# Altium schematic sheet 2.4G 数传.SchDoc
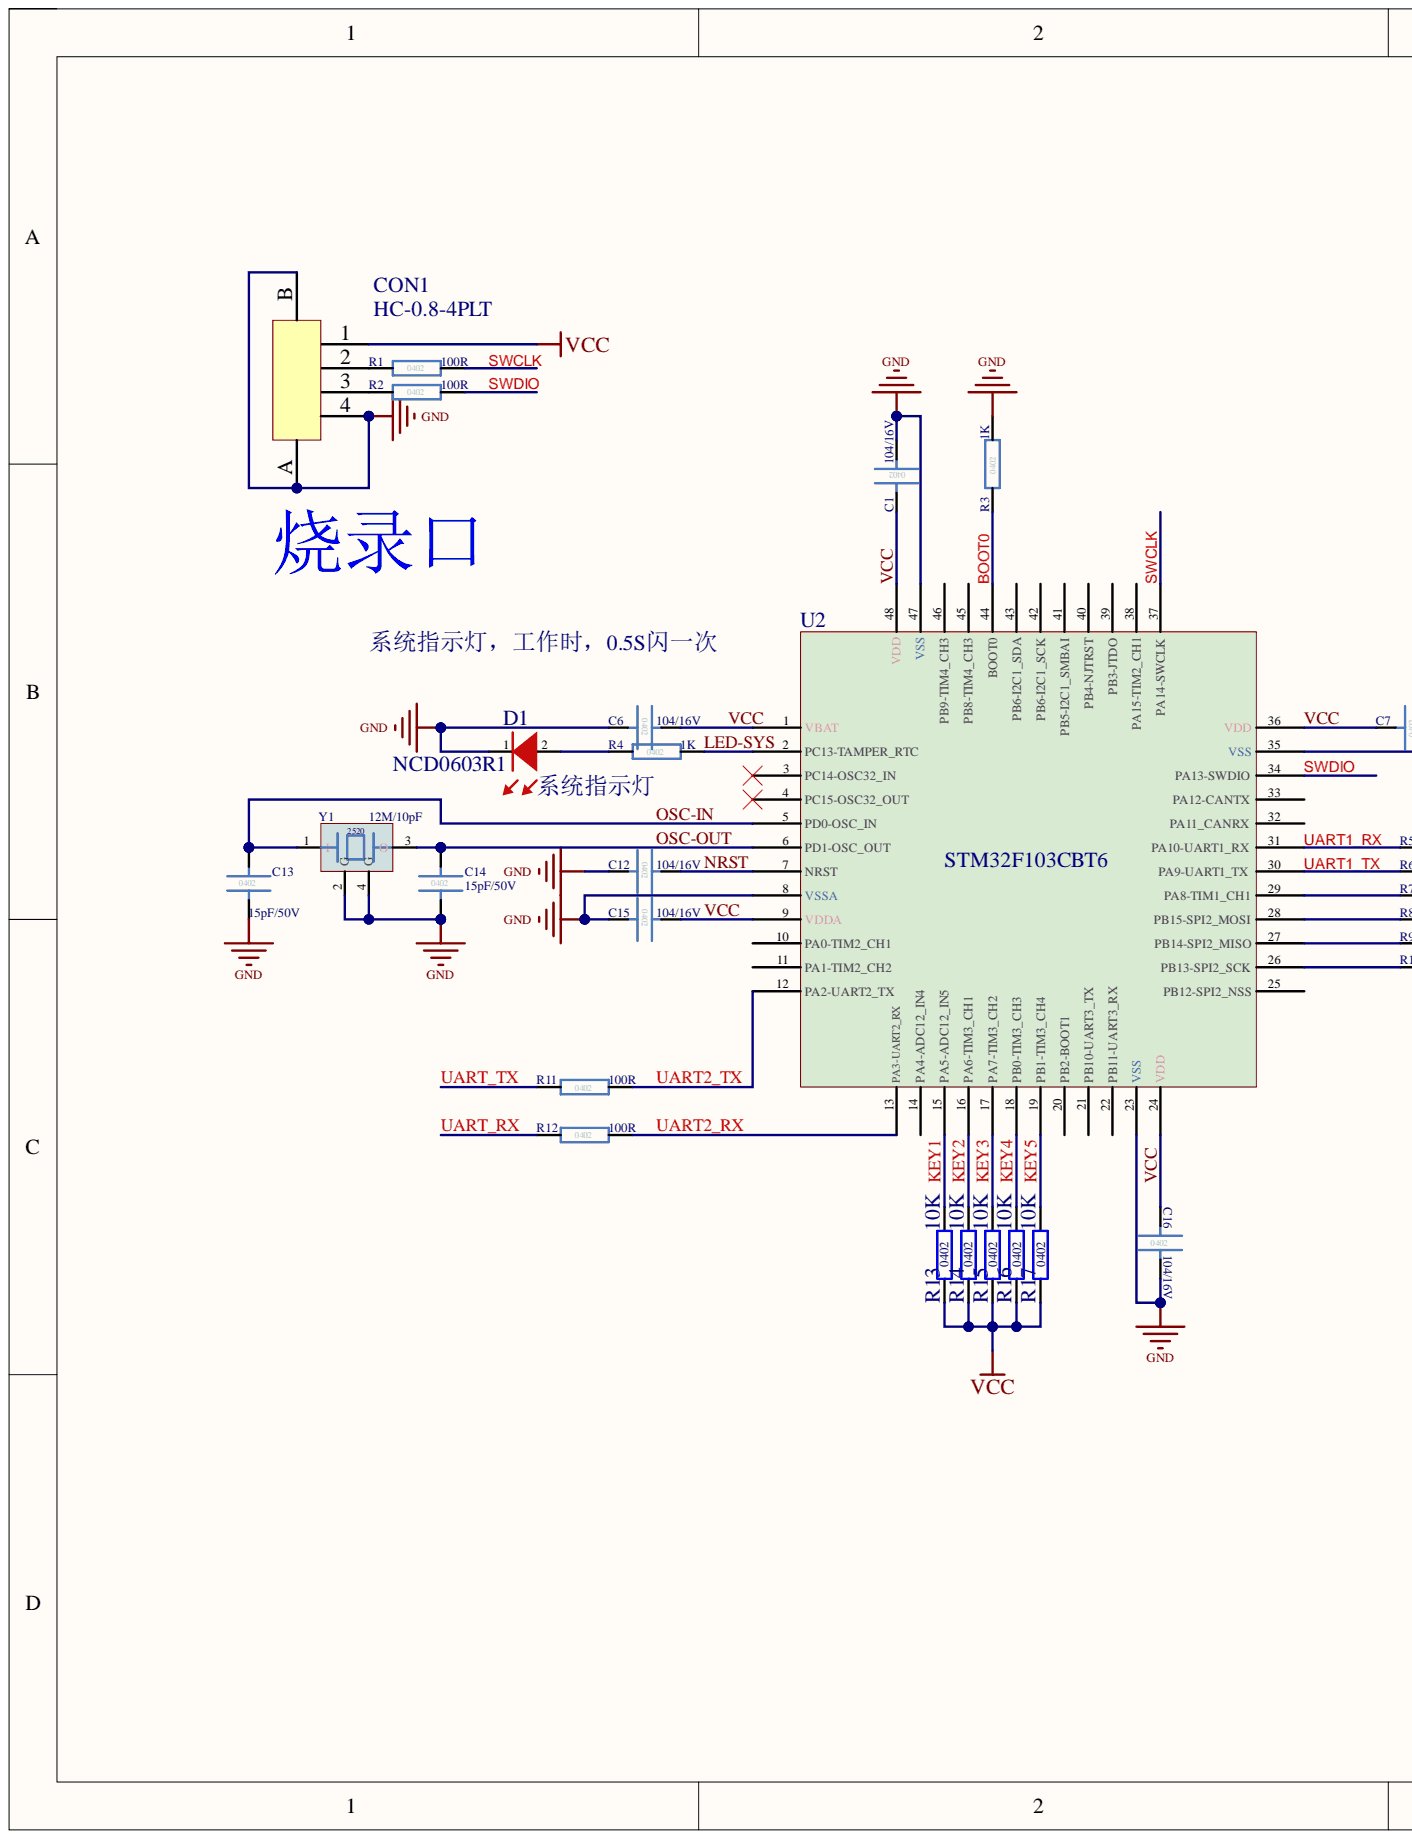
[diagram p0: 2.4G 数传.SchDoc, left]
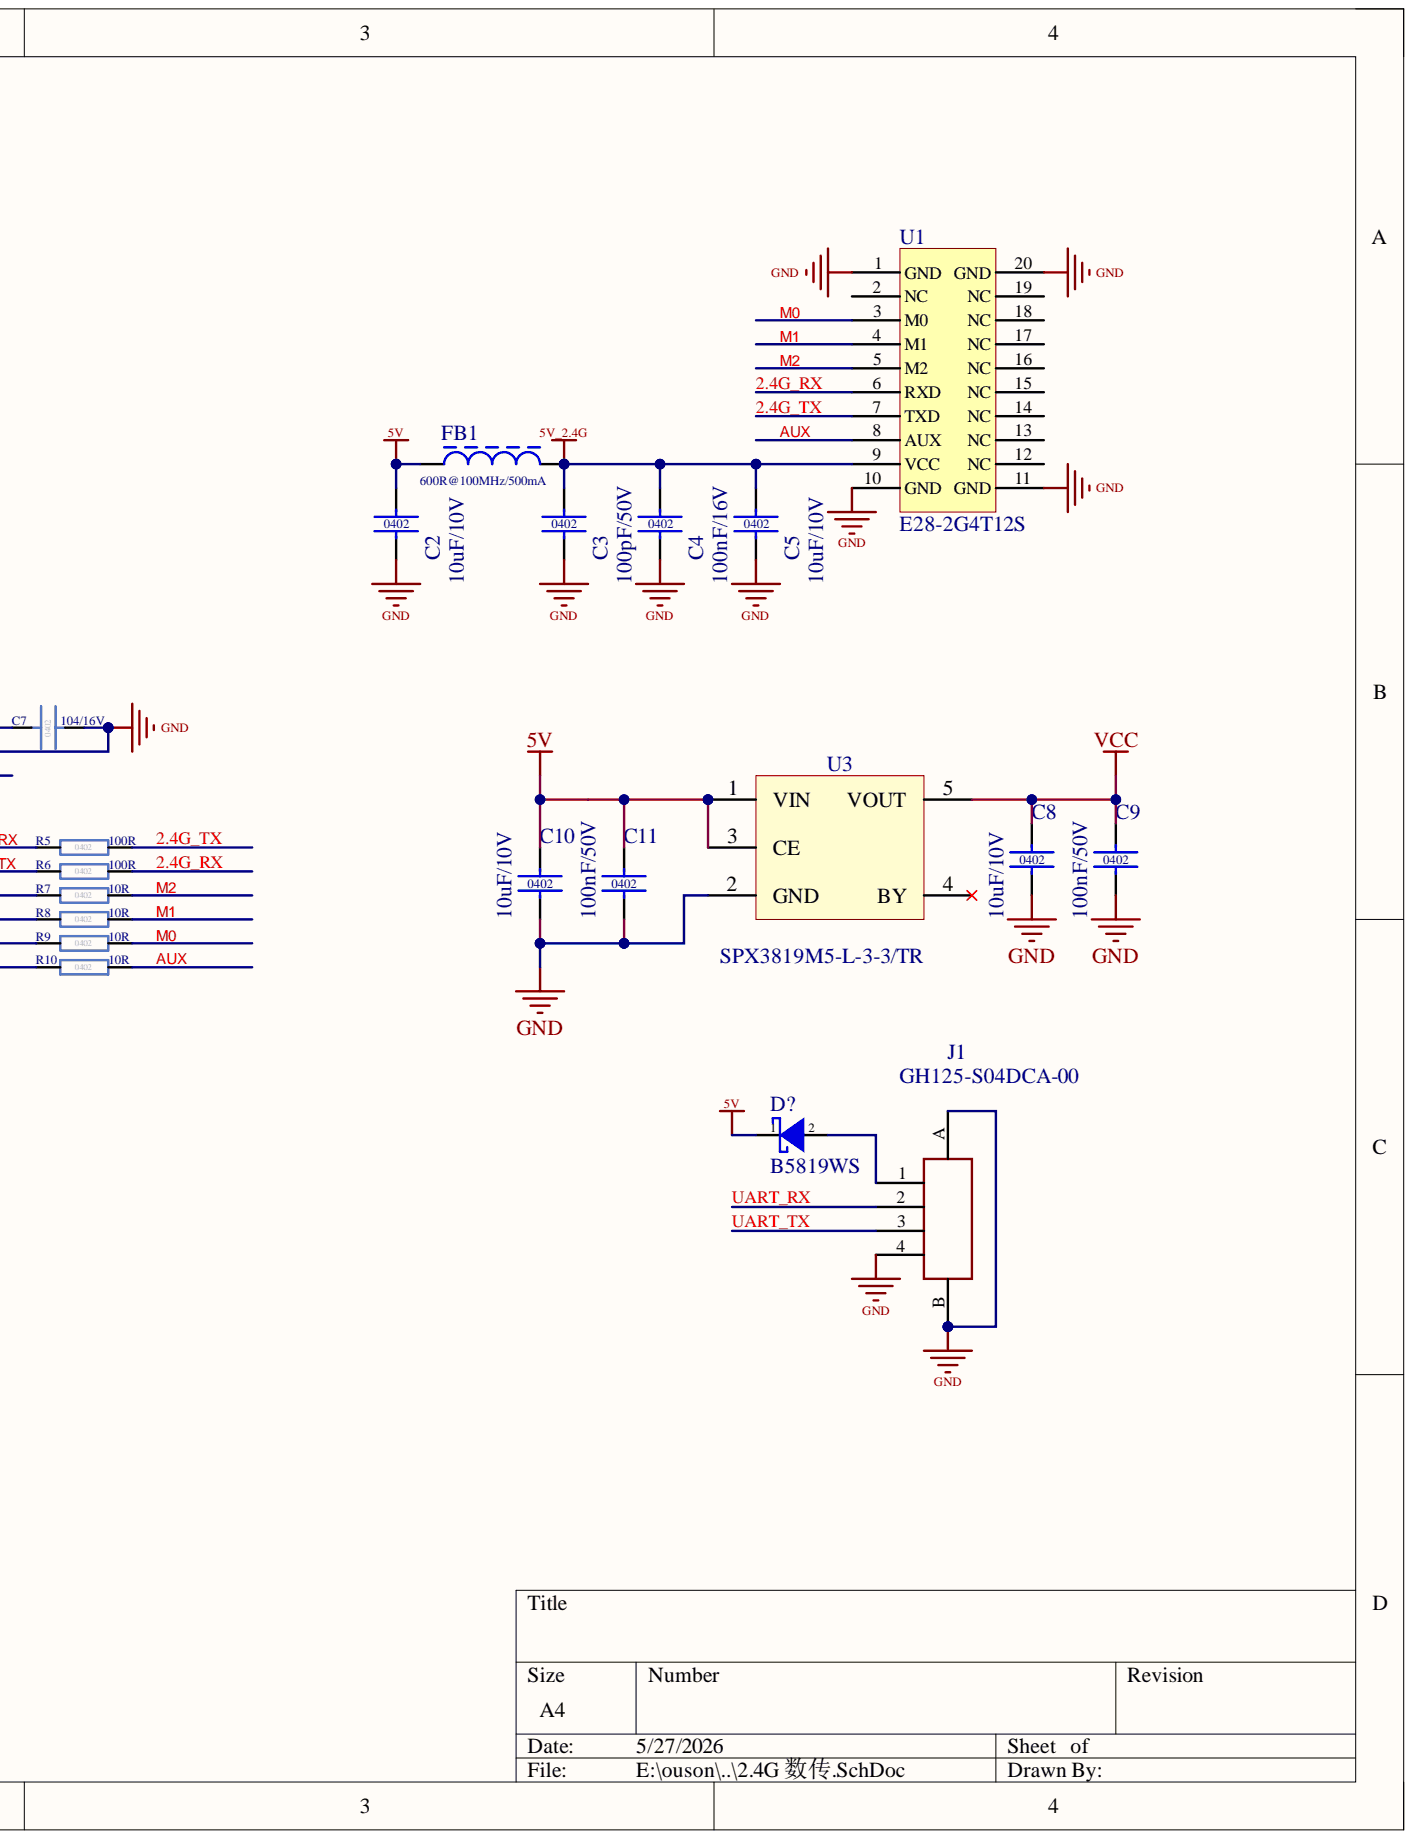
[diagram p1: 2.4G 数传.SchDoc, right]

## Parts
C1  104/16V  [C0402]
C2  10uF/10V  [C0402]
C3  100pF/50V  [C0402]
C4  100nF/16V  [C0402]
C5  10uF/10V  [C0402]
C6  104/16V  [C0402]
C7  104/16V  [C0402]
C8  10uF/10V  [C0402]
C9  100nF/50V  [C0402]
C10  10uF/10V  [C0402]
C11  100nF/50V  [C0402]
C12  104/16V  [C0402]
C13  15pF/50V  [C0402]
C14  15pF/50V  [C0402]
C15  104/16V  [C0402]
C16  104/16V  [C0402]
CON1  HC-0.8-4PLT  [GH0.8_4LT]
D1  NCD0603R1  [LED0603]
D?  B5819WS  [SOD323]
FB1  600R@100MHz/500mA  [L0402]
J1  GH125-S04DCA-00  [GH1.25_4WT]
R1  100R  [R0402]
R2  100R  [R0402]
R3  1K  [R0402]
R4  1K  [R0402]
R5  100R  [R0402]
R6  100R  [R0402]
R7  10R  [R0402]
R8  10R  [R0402]
R9  10R  [R0402]
R10  10R  [R0402]
R11  100R  [R0402]
R12  100R  [R0402]
R13  10K  [R0402]
R14  10K  [R0402]
R15  10K  [R0402]
R16  10K  [R0402]
R17  10K  [R0402]
U1  E28-2G4T12S  [E28-2.4G_SMD,28.7x17.5mm]
U2  STM32F103CBT6  [LQFP48_0.5]
U3  SPX3819M5-L-3-3/TR  [SOT23-5]
Y1  12M/10pF  [XT2520]

## Nets
5V: C2.1 C10.1 C11.1 D?.1 FB1.1 U3.1(VIN) U3.3(CE)
5V_2.4G: C3.1 C4.1 C5.1 FB1.2 U1.9(VCC)
GND: C1.2 C2.2 C3.2 C4.2 C5.2 C6.2 C7.2 C8.2 C9.2 C10.2 C11.2 C12.2 C13.2 C14.2 C15.2 C16.2 CON1.4 CON1.A CON1.B D1.1 J1.4 J1.A J1.B R3.2 U1.1(GND) U1.10(GND) U1.11(GND) U1.20(GND) U2.8(VSSA) U2.23(VSS) U2.35(VSS) U2.47(VSS) U3.2(GND) Y1.2(G) Y1.4(G)
2.4G_RX: R6.2 U1.6(RXD)
2.4G_TX: R5.2 U1.7(TXD)
AUX: R10.2 U1.8(AUX)
BOOT0: R3.1 U2.44(BOOT0)
KEY1: R13.2 U2.15(PA5-ADC12_IN5)
KEY2: R14.2 U2.16(PA6-TIM3_CH1)
KEY3: R15.2 U2.17(PA7-TIM3_CH2)
KEY4: R16.2 U2.18(PB0-TIM3_CH3)
KEY5: R17.2 U2.19(PB1-TIM3_CH4)
LED-SYS: R4.2 U2.2(PC13-TAMPER_RTC)
M0: R9.2 U1.3(M0)
M1: R8.2 U1.4(M1)
M2: R7.2 U1.5(M2)
NRST: C12.1 U2.7(NRST)
OSC-IN: C13.1 U2.5(PD0-OSC_IN) Y1.1(I)
OSC-OUT: C14.1 U2.6(PD1-OSC_OUT) Y1.3(O)
SWCLK: R1.2 U2.37(PA14-SWCLK)
SWDIO: R2.2 U2.34(PA13-SWDIO)
UART1_RX: R5.1 U2.31(PA10-UART1_RX)
UART1_TX: R6.1 U2.30(PA9-UART1_TX)
UART2_RX: R12.2 U2.13(PA3-UART2_RX)
UART2_TX: R11.2 U2.12(PA2-UART2_TX)
UART_RX: J1.2 R12.1
UART_TX: J1.3 R11.1
VCC: C1.1 C6.1 C7.1 C8.1 C9.1 C15.1 C16.1 CON1.1 R13.1 R14.1 R15.1 R16.1 R17.1 U2.1(VBAT) U2.9(VDDA) U2.24(VDD) U2.36(VDD) U2.48(VDD) U3.5(VOUT)
NetCON1_2: CON1.2 R1.1
NetCON1_3: CON1.3 R2.1
NetD1_2: D1.2 R4.1
NetD?_2: D?.2 J1.1
NetR7_1: R7.1 U2.29(PA8-TIM1_CH1)
NetR8_1: R8.1 U2.28(PB15-SPI2_MOSI)
NetR9_1: R9.1 U2.27(PB14-SPI2_MISO)
NetR10_1: R10.1 U2.26(PB13-SPI2_SCK)

Not connected: U1 2(NC) 12(NC) 13(NC) 14(NC) 15(NC) 16(NC) 17(NC) 18(NC) 19(NC); U2 3(PC14-OSC32_IN) 4(PC15-OSC32_OUT) 10(PA0-TIM2_CH1) 11(PA1-TIM2_CH2) 14(PA4-ADC12_IN4) 20(PB2-BOOT1) 21(PB10-UART3_TX) 22(PB11-UART3_RX) 25(PB12-SPI2_NSS) 32(PA11_CANRX) 33(PA12-CANTX) 38(PA15-TIM2_CH1) 39(PB3-JTDO) 40(PB4-NJTRST) 41(PB5-I2C1_SMBAI) 42(PB6-I2C1_SCK) 43(PB6-I2C1_SDA) 45(PB8-TIM4_CH3) 46(PB9-TIM4_CH3); U3 4(BY)

## Library data of the parts
C1 C6 C7 C12 C15 C16 = C0402: 0402/100nF/16V/±10％; Component Kind: Standard; Library Name: 20250219.SchLib
C2 C5 = 0402/10uF/10V/±20％: Database Table Name: 电容$; 序号: 10063; 物料名称: 贴片电容; Component Kind: Standard; Description: 0402/10uF/10V/±20％; Library Name: GD_AD_DBLIB.DbLib; 参数: 10uF/10V; 规格: 0402/10uF/10V/±20％
C3 = 0402/100pF/50V/±10％: Database Table Name: 电容$; 序号: 10018; 物料名称: 贴片电容; Component Kind: Standard; Description: 0402/100pF/50V/±10％; Library Name: GD_AD_DBLIB.DbLib; 参数: 100pF/50V; 规格: 0402/100pF/50V/±10％
C4 = 0402/100nF/16V/±10％: Database Table Name: 电容$; 序号: 10053; 物料名称: 贴片电容; Component Kind: Standard; Description: 0402/100nF/16V/±10％; Library Name: GD_AD_DBLIB.DbLib; 参数: 100nF/16V; 规格: 0402/100nF/16V/±10％
C8 C10 = 0402/10uF/10V/±20％: Database Table Name: 电容$; 序号: 10064; 物料名称: 贴片电容; Component Kind: Standard; Description: 0402/10uF/10V/±20％; Library Name: GD_AD_DBLIB.DbLib; 参数: 10uF/10V; 规格: 0402/10uF/10V/±20％
C9 C11 = 0402/100nF/50V/±10％: Database Table Name: 电容$; 序号: 10055; 物料名称: 贴片电容; Component Kind: Standard; Description: 0402/100nF/50V/±10％; Library Name: GD_AD_DBLIB.DbLib; 参数: 100nF/50V; 规格: 0402/100nF/50V/±10％
C13 C14 = C0402: 0402/15pF/50V/±10%; Component Kind: Standard; Library Name: 20250219.SchLib
CON1 = SH0.8mm/4PIN/立贴/HC-0.8-4PLT: Database Table Name: 按键连接器$; 厂商名称: HCTL(华灿天禄); 序号: 110022; 物料名称: 连接座; 物料编号: C2845354; Component Kind: Standard; Description: SH0.8mm/4PIN/立贴/HC-0.8-4PLT; Library Name: GD_AD_DBLIB.DbLib; 厂商型号: HC-0.8-4PLT; 参数: HC-0.8-4PLT; 规格: SH0.8mm/4PIN/立贴/HC-0.8-4PLT; 购买连接: https://item.szlcsc.com/3039035.html
D1 = 0603/20mA/红灯/发光二极管/NCD0603R1: Database Table Name: 二极管$; 厂商名称: 国星光电; 参数2: 发光颜色：红色 正向电压(VF)：1.6V~2.6V 功率：65mW 正向电流：20mA 主波长：615nm~630nm 二极管配置：独立二极管 透镜颜色：无色透明透镜 发光强度：80mcd~220mcd 发光角度：130° 工作温度：-30℃~+85℃; 序号: 40004; 物料名称: 发光二极管; 物料编号: C84263; Component Kind: Standard; Description: 0603/20mA/红灯/发光二极管/NCD0603R1; Library Name: GD_AD_DBLIB.DbLib; 厂商型号: NCD0603R1; 参数: NCD0603R1; 规格: 0603/20mA/红灯/发光二极管/NCD0603R1; 购买连接: https://item.szlcsc.com/85432.html
D? = SOD323/40V/1A/单向/肖特基二极管/B5819WS（长电）: Database Table Name: 二极管$; 厂商名称: CJ(江苏长电/长晶); 参数2: 正向压降(Vf)：600mV@1A 直流反向耐压(Vr)：40V 平均整流电流(Io)：1A; 序号: 40017; 物料名称: 肖特基二极管; 物料编号: C22624; Component Kind: Standard; Description: SOD323/40V/1A/单向/肖特基二极管/B5819WS（长电）; Library Name: GD_AD_DBLIB.DbLib; 厂商型号: B5819WS; 参数: B5819WS; 规格: SOD323/40V/1A/单向/肖特基二极管/B5819WS（长电）; 购买连接: https://item.szlcsc.com/23351.html
FB1 = 0402/600R@100MHz/500mA/±25％/BLM15AX601SN1D: Database Table Name: 电感$; 厂商名称: muRata(村田); 参数2: 标称阻抗@测试频率：600Ω@100MHz 误差：±25% 直流电阻(RDC)：340mΩ; 序号: 30002; 物料名称: 贴片磁珠; 物料编号: C76886; Component Kind: Standard; Description: 0402/600R@100MHz/500mA/±25％/BLM15AX601SN1D; Library Name: GD_AD_DBLIB.DbLib; 厂商型号: BLM15AX601SN1D; 参数: 600R@100MHz/500mA; 规格: 0402/600R@100MHz/500mA/±25％/BLM15AX601SN1D; 购买连接: https://item.szlcsc.com/78013.html
J1 = GH1.25_4WT: GH1.25mm/4PIN/卧贴/GH125-S04DCA-00; Component Kind: Standard; Library Name: 连接器.SchLib
R1 R2 R5 R6 R11 R12 = R0402: 0402/100R/±1％/62.5mW/厚膜电阻; Component Kind: Standard; Library Name: 20250219.SchLib
R3 R4 = R0402: 0402/1K/±1％/62.5mW/厚膜电阻; Component Kind: Standard; Library Name: 20250219.SchLib
R7-R10 = R0402: 0402/10R/±1％/62.5mW/厚膜电阻; Component Kind: Standard; Library Name: 20250219.SchLib
R13-R17 = 0402/10K/±1％/62.5mW/厚膜电阻: Database Table Name: 电阻$; 参数1: 10000; 序号: 20065; 物料名称: 贴片电阻; Component Kind: Standard; Description: 0402/10K/±1％/62.5mW/厚膜电阻; Library Name: GD_AD_DBLIB.DbLib; 参数: 10K; 规格: 0402/10K/±1％/62.5mW/厚膜电阻
U1 = E28-2G4T12S: SMD,28.7x17.5mm/2.4G模块/E28-2G4T12S (亿佰特); Component Kind: Standard; Library Name: IC芯片.SchLib
U2 = STM32F103CBT6: LQFP48/单片机/STM32F103CBT6（ST）; Component Kind: Standard; Library Name: 20250219.SchLib
U3 = SOT23-5/16V-3.3V/500mA/LDO稳压/SPX3819M5-L-3-3/TR（迈凌）: Database Table Name: 电源芯片$; 厂商名称: MaxLinear(迈凌); 参数2: 输出类型：固定 最大输入电压：16V 输出电压：3.3V 最大输出电流：500mA 电源纹波抑制比(PSRR)：70dB 输出极性：正极; 序号: 70007; 物料名称: 电源芯片; 物料编号: C9055; Component Kind: Standard; Description: SOT23-5/16V-3.3V/500mA/LDO稳压/SPX3819M5-L-3-3/TR（迈凌）; Library Name: GD_AD_DBLIB.DbLib; 厂商型号: SPX3819M5-L-3-3/TR; 参数: SPX3819M5-L-3-3/TR; 规格: SOT23-5/16V-3.3V/500mA/LDO稳压/SPX3819M5-L-3-3/TR（迈凌）; 购买连接: https://item.szlcsc.com/9575.html
Y1 = XT2520_12M: SMD2520-4P/12MHz/10pF/±10PPM/无源晶振/Q24FA20H00687; Component Kind: Standard; Library Name: 20250219.SchLib
